# Altium schematic sheet edr_pd.SchDoc
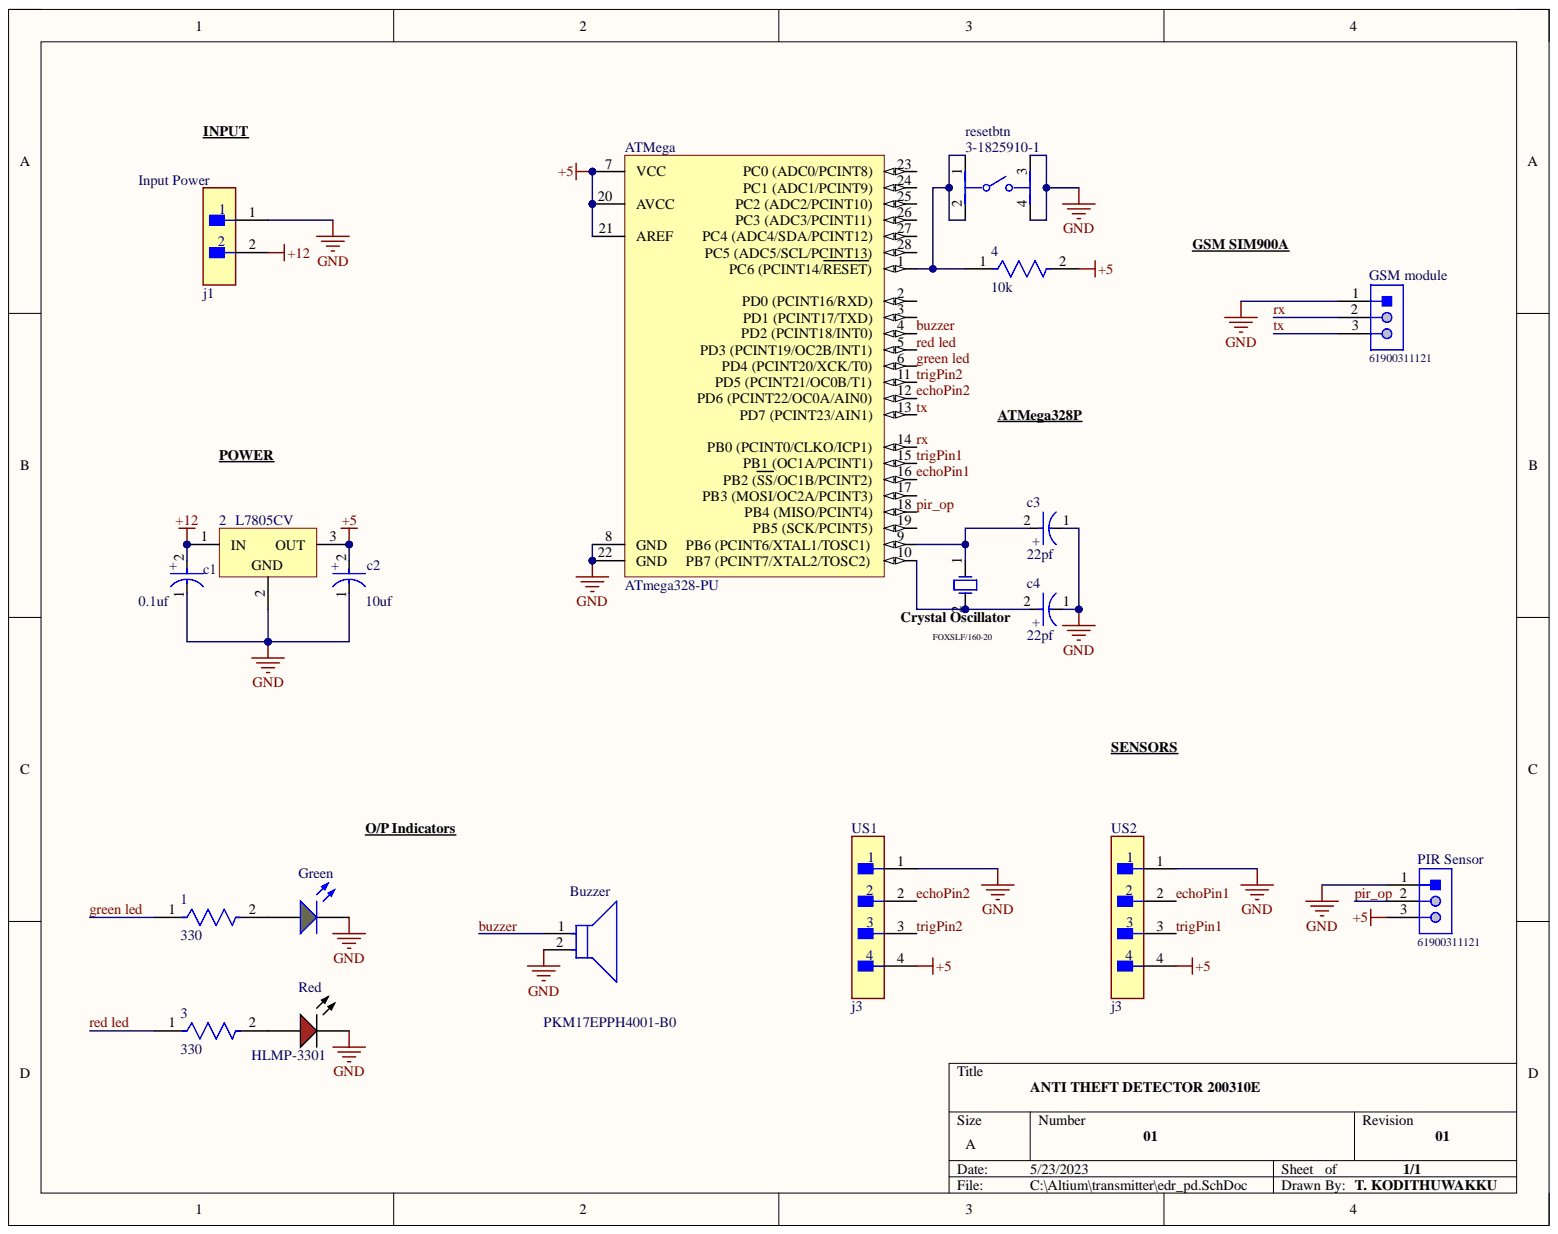
[diagram p0: edr_pd.SchDoc]

## Parts
1  330  [FP-RNC55-MFG]
2  L7805CV  [TO220]
3  330  [FP-RNC55-MFG]
4  10k  [FP-RNC55-MFG]
ATMega  ATmega328-PU  [28P3]
Buzzer  PKM17EPPH4001-B0  [FP-PKM17EPPH4001-B0-MFG]
c1  0.1uf  [FP-RAD-TH-D_10_0_5-L_20_2-MFG]
c2  10uf  [FP-RAD-TH-D_10_0_5-L_20_2-MFG]
c3  22pf  [FP-RAD-TH-D_10_0_5-L_20_2-MFG]
c4  22pf  [FP-RAD-TH-D_10_0_5-L_20_2-MFG]
Crystal_Oscillator  FOXSLF/160-20  [FOX-FOXSLF160-20]
Green  (no value)  [AVAG-HLMP-3507]
GSM_module  61900311121  [61900311121]
Input_Power  j1  [FP-22-04-1021-MFG]
PIR_Sensor  61900311121  [61900311121]
Red  HLMP-3301  Emitting Color: Red  [AVAG-HLMP-3301-2]
resetbtn  3-1825910-1  [FP-3-1825910-1-MFG]
US1  j3  [FP-47053-3000-MFG]
US2  j3  [FP-47053-3000-MFG]

## Nets
+5: 2.3 4.2 ATMega.7(VCC) ATMega.20(AVCC) ATMega.21(AREF) c2.2 PIR_Sensor.3 US1.4 US2.4
+12: 2.1 c1.2 Input_Power.2
GND: 2.2 ATMega.8(GND) ATMega.22(GND) Buzzer.2 c1.1 c2.1 c3.1 c4.1 Green.2 GSM_module.1 Input_Power.1 PIR_Sensor.1 Red.1 resetbtn.3 resetbtn.4 US1.1 US2.1
buzzer: ATMega.4(PD2_(PCINT18/INT0)) Buzzer.1
echoPin1: ATMega.16(PB2_(SS/OC1B/PCINT2)) US2.2
echoPin2: ATMega.12(PD6_(PCINT22/OC0A/AIN0)) US1.2
green led: 1.1 ATMega.6(PD4_(PCINT20/XCK/T0))
pir_op: ATMega.18(PB4_(MISO/PCINT4)) PIR_Sensor.2
red led: 3.1 ATMega.5(PD3_(PCINT19/OC2B/INT1))
rx: ATMega.14(PB0_(PCINT0/CLKO/ICP1)) GSM_module.2
trigPin1: ATMega.15(PB1_(OC1A/PCINT1)) US2.3
trigPin2: ATMega.11(PD5_(PCINT21/OC0B/T1)) US1.3
tx: ATMega.13(PD7_(PCINT23/AIN1)) GSM_module.3
Net1_2: 1.2 Green.1
Net3_2: 3.2 Red.2
Net4_1: 4.1 ATMega.1(PC6_(PCINT14/RESET)) resetbtn.1 resetbtn.2
NetATMega_9: ATMega.9 c3.2 Crystal_Oscillator.1
NetATMega_10: ATMega.10 c4.2 Crystal_Oscillator.2

Not connected: ATMega 2(PD0_(PCINT16/RXD)) 3(PD1_(PCINT17/TXD)) 17(PB3_(MOSI/OC2A/PCINT3)) 19(PB5_(SCK/PCINT5)) 23(PC0_(ADC0/PCINT8)) 24(PC1_(ADC1/PCINT9)) 25 26(PC3_(ADC3/PCINT11)) 27 28(PC5_(ADC5/SCL/PCINT13))

## Library data of the parts
1 3 4 = CMP-02408-000004-1: RES 10K OHM 1/8W 1% AXIAL; Manufacturer: Vishay; Manufacturer Part Number: RNC55H1002FSB14; Power Rating: 0.125W; Resistance: 10kΩ; Temperature Coefficient: 50ppm/°C; Value: 10kΩ; Voltage Rating: 200V; Automotive: No; Category: Res; Component Kind: Standard; ComponentLink2Description: Datasheet; ComponentLink2URL: https://www.vishay.com/docs/31025/erc.pdf; Composition: Metal Film; Device Class L1: Passive Components; Device Class L2: Resistors; Device Class L3: Through-Hole Resistors; Digikey Description: RES 10K OHM 1/8W 1% AXIAL; Failure Rate: 0.001%; Height: 2.69mm; Ipc Land Pattern Name: RESAD1100W85L762D269; Lead Free: No; Library Name: Altium Content Vault; Military Standard: true; Octopart: https://octopart.com/rnc55h1002fs-vishay-40848808; Package: RNC55; RoHS: FALSE; Series: RNC; Temperature: -65°C to +175°C; Temperature Range High: +175°C; Temperature Range Low: -65°C; Tolerance: 1%
2 = CMP-0244-00483-1: Positive Voltage Regulator, 5V, 3-Pin TO-220; Component Kind: Standard; ComponentLink1Description: Manufacturer URL; ComponentLink1URL: http://www.st.com; ComponentLink2Description: Datasheet; ComponentLink2URL: http://www.st.com/internet/com/TECHNICAL_RESOURCES/TECHNICAL_LITERATURE/DATASHEET/CD00000444.pdf; ComponentLink3Description: Package Specification; ComponentLink3URL: http://www.st.com/internet/com/TECHNICAL_RESOURCES/TECHNICAL_LITERATURE/PACKAGE_INFORMATION/CD00004649.pdf; DatasheetVersion: 16/11/2011; Library Name: Altium Content Vault; PackageDescription: TO, Thru-Hole, Vertical, Heatsink Mounted; 3 In-Line Leads; Pitch 2.54 mm; PackageReference: TO220; PackageVersion: ver3.0
ATMega = CMP-0095-00015-2: 8-bit AVR Microcontroller, 32KB Flash, 1KB EEPROM, 2KB SRAM, 28-pin PDIP, Industrial Grade (-40°C to 85°C); Component Kind: Standard; ComponentLink1Description: Manufacturer URL; ComponentLink1URL: http://www.atmel.com/; ComponentLink2Description: ATmega48A/48PA/88A/88PA/168A/168PA/328/328P Datasheet; ComponentLink2URL: http://www.atmel.com/dyn/resources/prod_documents/8271.pdf; ComponentLink3Description: ATmega48A/48PA/88A/88PA/168A/168PA/328/328P Summary; ComponentLink3URL: http://www.atmel.com/dyn/resources/prod_documents/8271S.pdf; DatasheetVersion: revD, May-2011; Library Name: Altium Content Vault; PackageDescription: 28-lead (0.300"/7.62mm Wide) Plastic Dual Inline Package (PDIP); PackageReference: 28P3; PackageVersion: revB, Sep-2001; RevisionNote: DatasheetVersion updated. URLs added.
Buzzer = CMP-06202-000027-1: AUDIO PIEZO TRANSDUCER 25V TH; Capacitance: 7nF; Frequency: 4kHz; Nominal Input Voltage: 3V; Washable: No; Component Kind: Standard; Diameter: 17mm; Height: 7mm; Lead Free: Lead Free; Library Name: Altium Content Vault; Max Frequency: 4kHz; Max Operating Temperature: 70°C; Max Supply Voltage: 25V; Min Operating Temperature: -20°C; Mount: Through Hole; Package Quantity: 600; Packaging: Bulk; Radiation Hardening: No; REACH SVHC: No SVHC; Self Resonant Frequency: 4kHz; Termination: Solder; Voltage Rating: 3V; Voltage Rating (DC): 25V
c1-c4 = CMP-05427-000214-1: Aluminum Electrolytic Capacitors (Radial Lead Type) 10uF ±20% 400V; Dielectric Material: Aluminium; Dissipation Factor: 0.24%; Lead Diameter: 0.6mm; Lead/Base Style: Straight; Life (Hours): 2000Hour; Polarity: Polar; Ripple Current: 80mA; Capacitance: 10uF; Case/Package: Radial; Component Kind: Standard; Construction: RADIAL; Contact Plating: Tin; Diameter: 10mm; Height: 20mm; Height - Seated (Max): 0.866inch; Lead Free: Lead Free; Lead Pitch: 5mm; Length: 20mm; Library Name: Altium Content Vault; Max Operating Temperature: 105°C; Min Operating Temperature: -25°C; Mount: Through Hole; Number of Pins: 2; Package Quantity: 200; Packaging: Bulk; Radiation Hardening: No; REACH SVHC: No SVHC; Ripple Current (AC): 80mA; RoHS Compliant: Yes; Series: NHG; Termination: Radial; Tolerance: 20%; Voltage: 400V; Voltage Rating: 400V; Voltage Rating (DC): 400V
Crystal_Oscillator = CMP-1749-00003-1: Resistance Weld Thru-Hole Crystal, 16 MHz, -20 to 70 degC, 2-Pin THD, RoHS, Bulk; Case\Package: Axial; Power Rating: 250 mW; Resistance: 221 kohm; Tolerance: 1%; Voltage Rating: 250 V; Component Kind: Standard; ComponentLink1Description: Manufacturer URL; ComponentLink1URL: http://www.foxonline.com/; ComponentLink2Description: Datasheet; ComponentLink2URL: http://www.foxonline.com/pdfs/hc49slf.pdf; DatasheetVersion: Mar-15; Length: 0.248 inch; Library Name: Altium Content Vault; Max Operating Temperature: 155 degC; Min Operating Temperature: -55 degC; Mount: Through Hole; Package Quantity: 200; PackageDescription: 2-Pin Through Hole Device, Body 11.35 x 4.65 mm; PackageReference: FOXSLF160-20; Packaging: Bulk; RoHSCompliant: true
Green = CMP-2000-06412-1: T-1 3/4 (5 mm) Diffused LED Lamp, Green, 2.1 V, -20 to 100 degC, 2-Pin THD, RoHS, Bulk; Manufacturer: Avago; Chip Material: GaP; DELETED: Compliant; Dominant Wave Length: 569nm; Emitting Color: YellowGreen; Forward Current: 25mA; Forward Voltage: 2.7V; High Brightness: No; Lens Type: ColoredDiffused; Lum Intensity: 4.2mcd; Main Category: StandardLED; Number of  Elements: 1; Peak Wavelength: 565nm; Power Dissipation: 85W; Product Depth (mm): 6.1mm; Product Height (mm): 9.19mm; Reverse Voltage: 5V; Shape Type: Circular; Test Current (It): 10mA; Viewing Angle: 60deg; Component Kind: Standard; Datasheet URL: http://docs.avagotech.com/docs/AV02-1558EN; Datasheet Version: 03/2015; Lens Dimensions: 5.08x5.08x8.3mm; Library Name: Altium Content Vault; Luminous Intensity: 4.2mcd; Manufacturer URL: http://www.avagotech.com/; Mounting: ThroughHole; Mounting Technology: ThroughHole; Operating Temp Range: -40Cto100C; Operating Temperature Classification: Industrial; Package Description: 2-Pin Through Hole Device, Dia 5.845 mm, Pitch 2.54 mm; Package Reference: HLMP-3507; Package Type: T-13/4; Pin Count: 2; Product Length (mm): 6.1mm; Rad Hardened: No; Type: Uni-Color
GSM_module PIR_Sensor = CMP-1502-00585-1: Male Locking Header WR-WTB, THT, Vertical, pitch 2.54 mm, 1 x 3 position; Manufacturer: Wurth Elektronik; Contact Resistance: 20mOhm; Pitch: 2.54mm; Position: 1x3; Working Voltage: 250VAC; Component Kind: Standard; Datasheet URL: https://katalog.we-online.de/em/datasheet/6190xx11121.pdf; Gender: Male; Library Name: Altium Content Vault; Manufacturer URL: http://www.we-online.de; Mounting Technology: THT; Operating Temperature: -40to105degC; Orientation: Vertical; Package Reference: 61900311121; Packaging: Bag; Part Number: 61900311121; Publisher: WurthElektronik
Input_Power = CMP-04776-000257-1: CONN HEADER VERT 2POS 2.5MM; Contact Post Length: 0.134inch; Gender: Male; Glow Wire Compliant: Non-compliant; Insulation Resistance: 1GR; Number of Circuits: 2; Voltage Rating (DC): 250V; Component Kind: Standard; Connector Type: Header; Contact Material: Tin; Contact Mating Length: 0.295inch; Contact Plating: Tin; Contact Shape: Square; Contact Type: -; Fastening Type: Friction; Flammability Rating: UL94 V-0; Halogen Free: Low Halogen; Insulation Color: Natural; Insulation Height: 0.461inch; Insulation Material: Nylon; Lead Free: Lead Free; Library Name: Altium Content Vault; Mount: Through Hole; Number of Positions: 2; Number of Rows: 1; Package Quantity: 1000; Packaging: Bulk; RoHS Compliant: Yes; Series: 5045; Termination: Through Hole; Weight: 0.007055oz
Red = CMP-2000-06411-1: T-1 3/4 (5 mm) Diffused LED Lamp, 1.9 V, -40 to 100 degC, 2-Pin THD, RoHS, Bulk; Manufacturer: Avago; Chip Material: GaP; DELETED: Compliant; Dominant Wave Length: 626nm; Forward Current: 25mA; Forward Voltage: 2.4V; High Brightness: No; Lens Type: ColoredDiffused; Lum Intensity: 5.4mcd; Main Category: StandardLED; Number of  Elements: 1; Peak Wavelength: 635nm; Power Dissipation: 85W; Product Depth (mm): 6.1mm; Product Height (mm): 9.19mm; Reverse Voltage: 5V; Shape Type: Circular; Test Current (It): 10mA; Viewing Angle: 60deg; Component Kind: Standard; Datasheet URL: http://www.avagotech.com/docs/AV02-1558EN; Datasheet Version: 03/2015; Lens Dimensions: 5.08x5.08x8.3mm; Library Name: Altium Content Vault; Luminous Intensity: 5.4mcd; Manufacturer URL: http://www.avagotech.com/; Mounting: ThroughHole; Mounting Technology: ThroughHole; Operating Temp Range: -40Cto100C; Operating Temperature Classification: Industrial; Package Description: 2-Pin Through Hole LED, Dia 5.85 mm; Package Reference: HLMP-3301; Package Type: T-13/4; Packaging: TapeandReel; Pin Count: 2; Product Length (mm): 6.1mm; Rad Hardened: No; Type: Uni-Color
resetbtn = CMP-03407-000001-1: SWITCH TACTILE SPST-NO 0.05A 24V; Manufacturer: TE Connectivity; Contact Current Rating: 50mA; Contact Resistance: 100mΩ; Electromechanical Life: 100000Cycles; Operating Force: 160gf; Automotive: No; Category: Switch; Component Kind: Standard; ComponentLink2Description: Datasheet; ComponentLink2URL: https://www.te.com/commerce/DocumentDelivery/DDEController?Action=srchrtrv&DocNm=1825910&DocType=Customer+Drawing&DocLang=English; Device Class L1: Electromechanical; Device Class L2: Switches; Device Class L3: Tactile Switches; Digikey Description: SWITCH TACTILE SPST-NO 0.05A 24V; Height: 17.3mm; Lead Free: Yes; Library Name: Altium Content Vault; Manufacturer Part Number: 3-1825910-1; Mount: Through Hole; Octopart: https://octopart.com/3-1825910-1-te+connectivity-46506874; Package: 0; RoHS: TRUE; Temperature: -35°C to +85°C; Temperature Range High: +85°C; Temperature Range Low: -35°C; Throw Configuration: SPST-NO
US1 US2 = CMP-04776-000447-1: CONN HEADER VERT 4POS 2.54MM; Current Rating: 4A; Gender: Male; Glow Wire Compliant: Non-compliant; Number of Circuits: 4; Voltage Rating: 250V; Component Kind: Standard; Contact Material: Copper Alloy; Contact Plating: Tin; Halogen Free: Low Halogen; Library Name: Altium Content Vault; Max Operating Temperature: 75°C; Min Operating Temperature: 0°C; Mount: Through Hole; Number of Contacts: 4; Number of Positions: 4; Number of Rows: 1; Orientation: Straight; Package Quantity: 12000; Packaging: Bulk; Pitch: 2.54mm; REACH SVHC: No SVHC; RoHS Compliant: Yes; Series: 47053; Termination: Through Hole
